# Altium schematic sheet TF卡.SchDoc(TF卡)
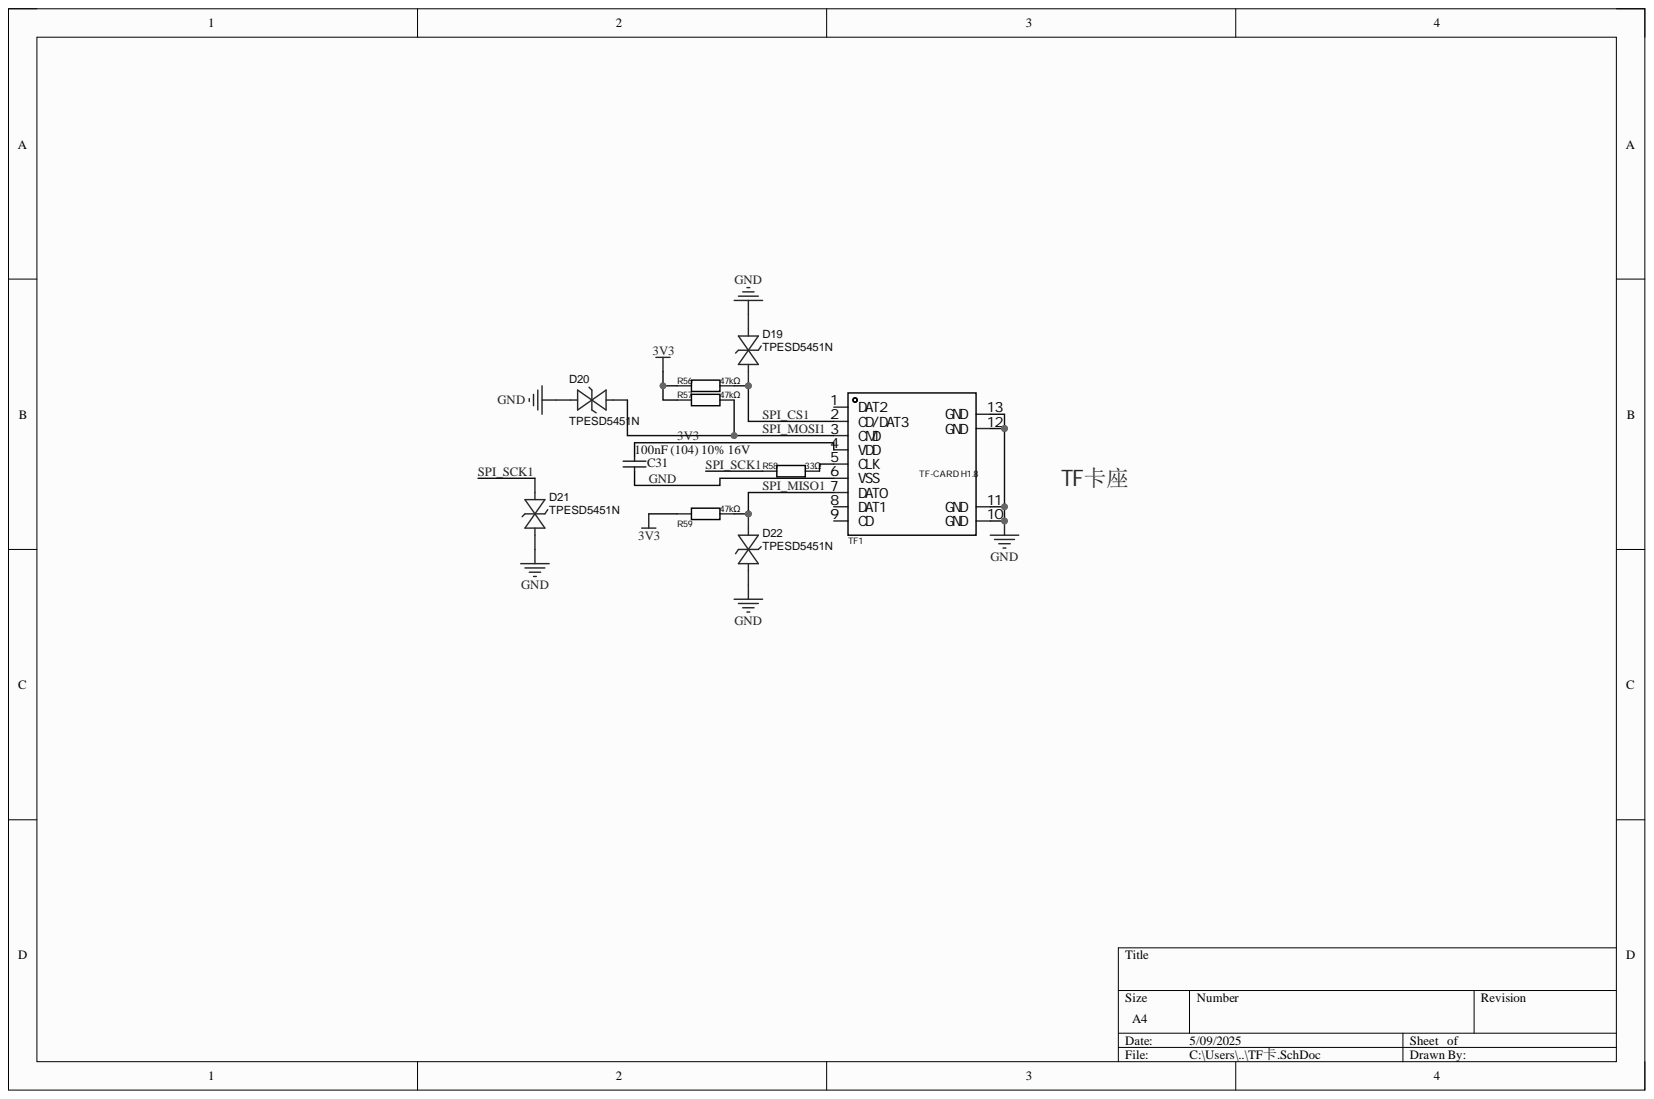
[diagram p0: TF卡.SchDoc]

## Parts
C31  100nF (104) 10% 16V  [0402_C]
D19  TPESD5451N  [DFN1006-2L-BI]
D20  TPESD5451N  [DFN1006-2L-BI]
D21  TPESD5451N  [DFN1006-2L-BI]
D22  TPESD5451N  [DFN1006-2L-BI]
R56  47kΩ  [0402_R]
R57  47kΩ  [0402_R]
R58  33Ω  [0402_R]
R59  47kΩ  [0402_R]
TF1  TF-CARD H1.8  [TF-SMD_TF-CARD-H1.8]

## Nets
3V3: C31.2 R56.1 R57.1 R59.1 TF1.4(VDD)
GND: C31.1 D19.1 D20.2 D21.2 D22.1 TF1.6(VSS) TF1.10(GND) TF1.11(GND) TF1.12(GND) TF1.13(GND)
SPI_CS1: D19.2 R56.2 TF1.2(CD/DAT3)
SPI_MISO1: D22.2 R59.2 TF1.7(DAT0)
SPI_MOSI1: D20.1 R57.2 TF1.3(CMD)
SPI_SCK1: D21.1 R58.1
NetR58_2: R58.2 TF1.5(CLK)

Not connected: TF1 1(DAT2) 8(DAT1) 9(CD)

## Library data of the parts
C31 = 0402 100nF (104) 10% 16V: 贴片电容; Component Kind: Standard; ComponentLink1Description: 供应商链接; ComponentLink1URL: http://www.szlcsc.com/product/details_1877.html; Library Name: Miscellaneous Devices LC.IntLib; Package: 0402; Supplier: LC; SuppliersPartNumber: C1525
D19-D22 = TPESD5451N: Manufacturer: TECH PUBLIC(台舟); Contributor: LCSC; Component Kind: Standard; JLCPCB Part Class: Extended Part; link: https://so.szlcsc.com/global.html?k=C970008&ref=editor; Manufacturer Part: TPESD5451N; spicePre: D; spiceSymbolName: TPESD5451N; Supplier: LCSC; Supplier Part: C970008
R56 R57 R59 = 0402WGF4702TCE: Manufacturer: UNI-ROYAL(厚声); Add into BOM: yes; Overload Voltage (Max): 50V; Power(Watts): 62.5mW; Temperature Coefficient: ±100ppm/℃; Tolerance: ±1%; Component Kind: Standard; Convert to PCB: yes; Datasheet: https://atta.szlcsc.com/upload/public/pdf/source/20200306/C422600_1E6D84923E4A46A82E41ADD87F860B5C.pdf; Device: 0402WGF4702TCE; JLCPCB Part Class: Basic Part; LCSC Part Name: 47kΩ ±1% 62.5mW 厚膜电阻; Manufacturer Part: 0402WGF4702TCE; Operating Temperature Range: -55℃~+155℃; PCB3D: R0402_L1.0-W0.5-H0.5; Supplier: LCSC; Supplier Footprint: 0402; Supplier Part: C25792; Symbol: 0402WGF4702TCE; Type: 厚膜电阻; Value: 47kΩ
R58 = 0402WGF330JTCE: 33欧电阻; Manufacturer: UNI-ROYAL(厚声); Add into BOM: yes; Overload Voltage (Max): 50V; Power(Watts): 62.5mW; Temperature Coefficient: ±100ppm/℃; Tolerance: ±1%; Component Kind: Standard; Convert to PCB: yes; Datasheet: https://atta.szlcsc.com/upload/public/pdf/source/20200306/C422600_1E6D84923E4A46A82E41ADD87F860B5C.pdf; Device: 0402WGF330JTCE; JLCPCB Part Class: Basic Part; LCSC Part Name: 33Ω ±1% 62.5mW 厚膜电阻; Library Name: Schlib2.SchLib; Manufacturer Part: 0402WGF330JTCE; Operating Temperature Range: -55℃~+155℃; PCB3D: R0402_L1.0-W0.5-H0.5; Supplier: LCSC; Supplier Footprint: 0402; Supplier Part: C25105; Symbol: 0402WGF330JTCE; Type: 厚膜电阻; Value: 33Ω
TF1 = TF-CARD H1.8: TF 卡槽; Manufacturer: SHOU HAN(首韩); Add into BOM: yes; Card Connection Mode: 自弹式; Card Type: MicroSD卡(TF卡); Connector Type: 卡座; Maximum Body Height: 1.8mm; Component Kind: Standard; Contact Material: 铜合金; Contact Plating: 金; Convert to PCB: yes; Datasheet: https://atta.szlcsc.com/upload/public/pdf/source/20230818/611DB5E7B45C91025B0B37F957961A64.pdf; Device: TF-CARD H1.8; JLCPCB Part Class: Extended Part; LCSC Part Name: 自弹式 MicroSD卡(TF卡) 卡座; Library Name: Schlib2.SchLib; Manufacturer Part: TF-CARD H1.8; Operating Temperature Range: -40℃~+70℃; PCB3D: TF-SMD_TF-CARD-H1.8; Supplier: LCSC; Supplier Footprint: SMD; Supplier Part: C7529389; Symbol: TF-CARD H1.8
